# Altium schematic sheet Fonte 24V 15A FAN gnd isolado.SchDoc
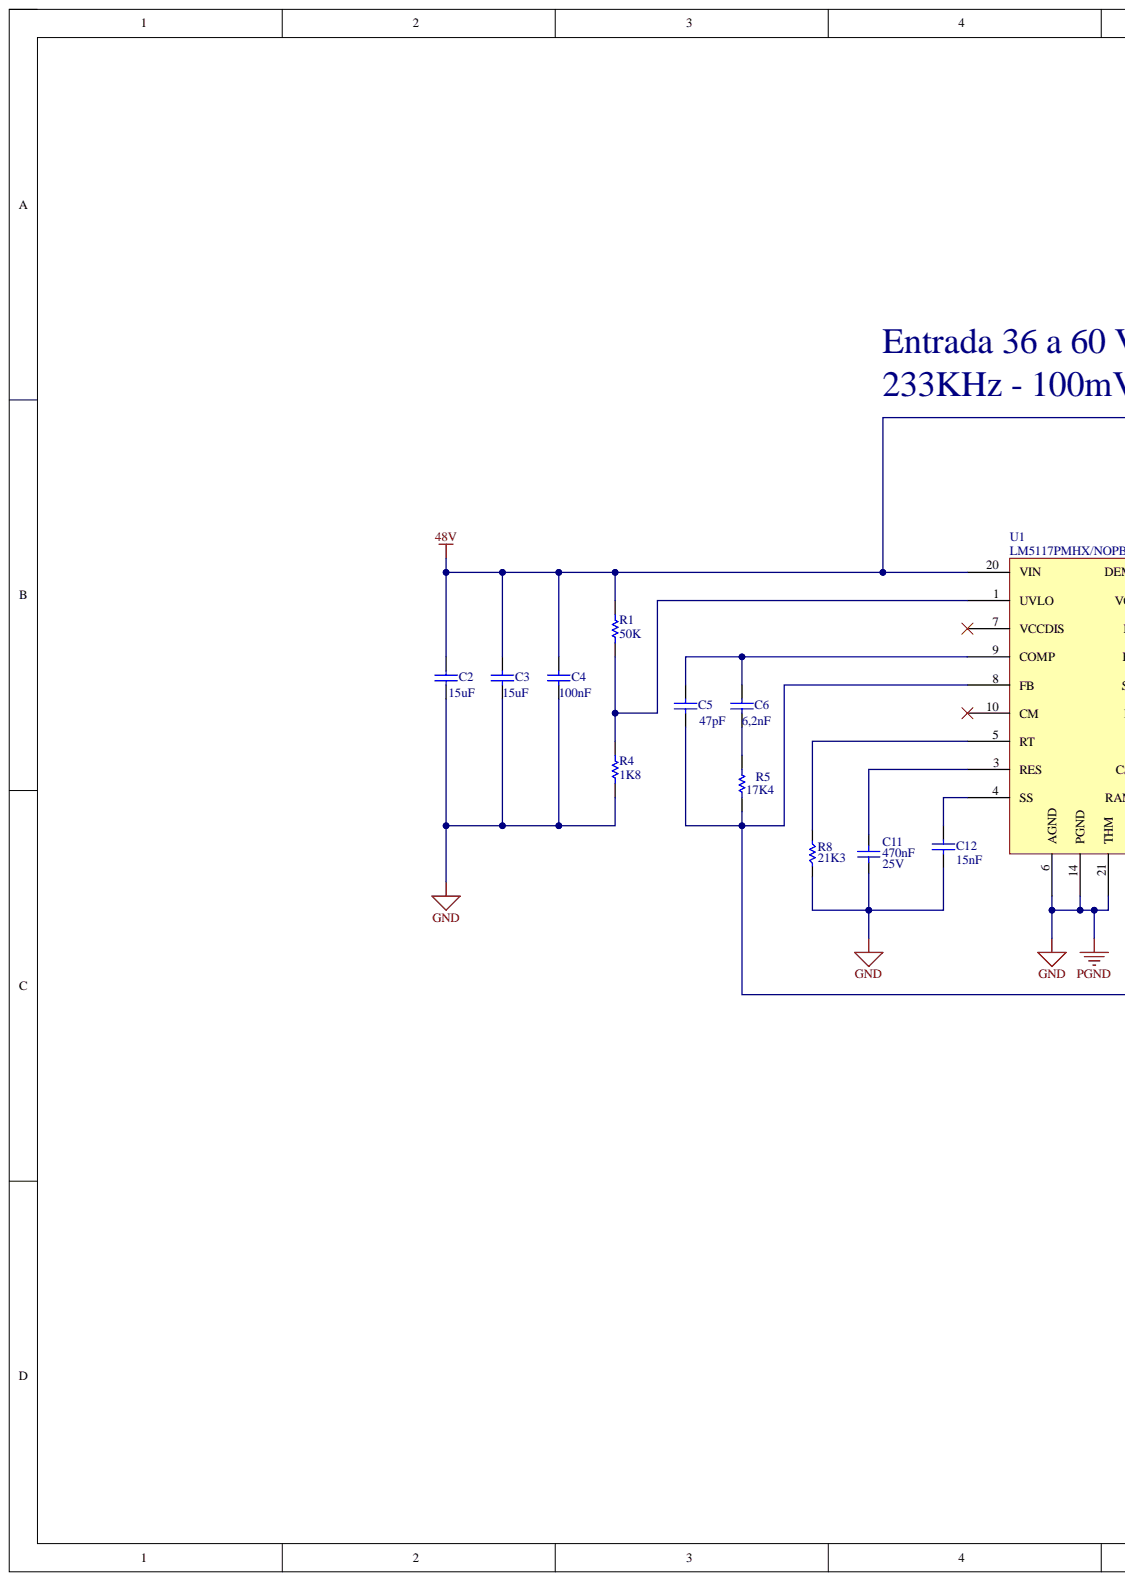
[diagram p0: Fonte 24V 15A FAN gnd isolado.SchDoc, left]
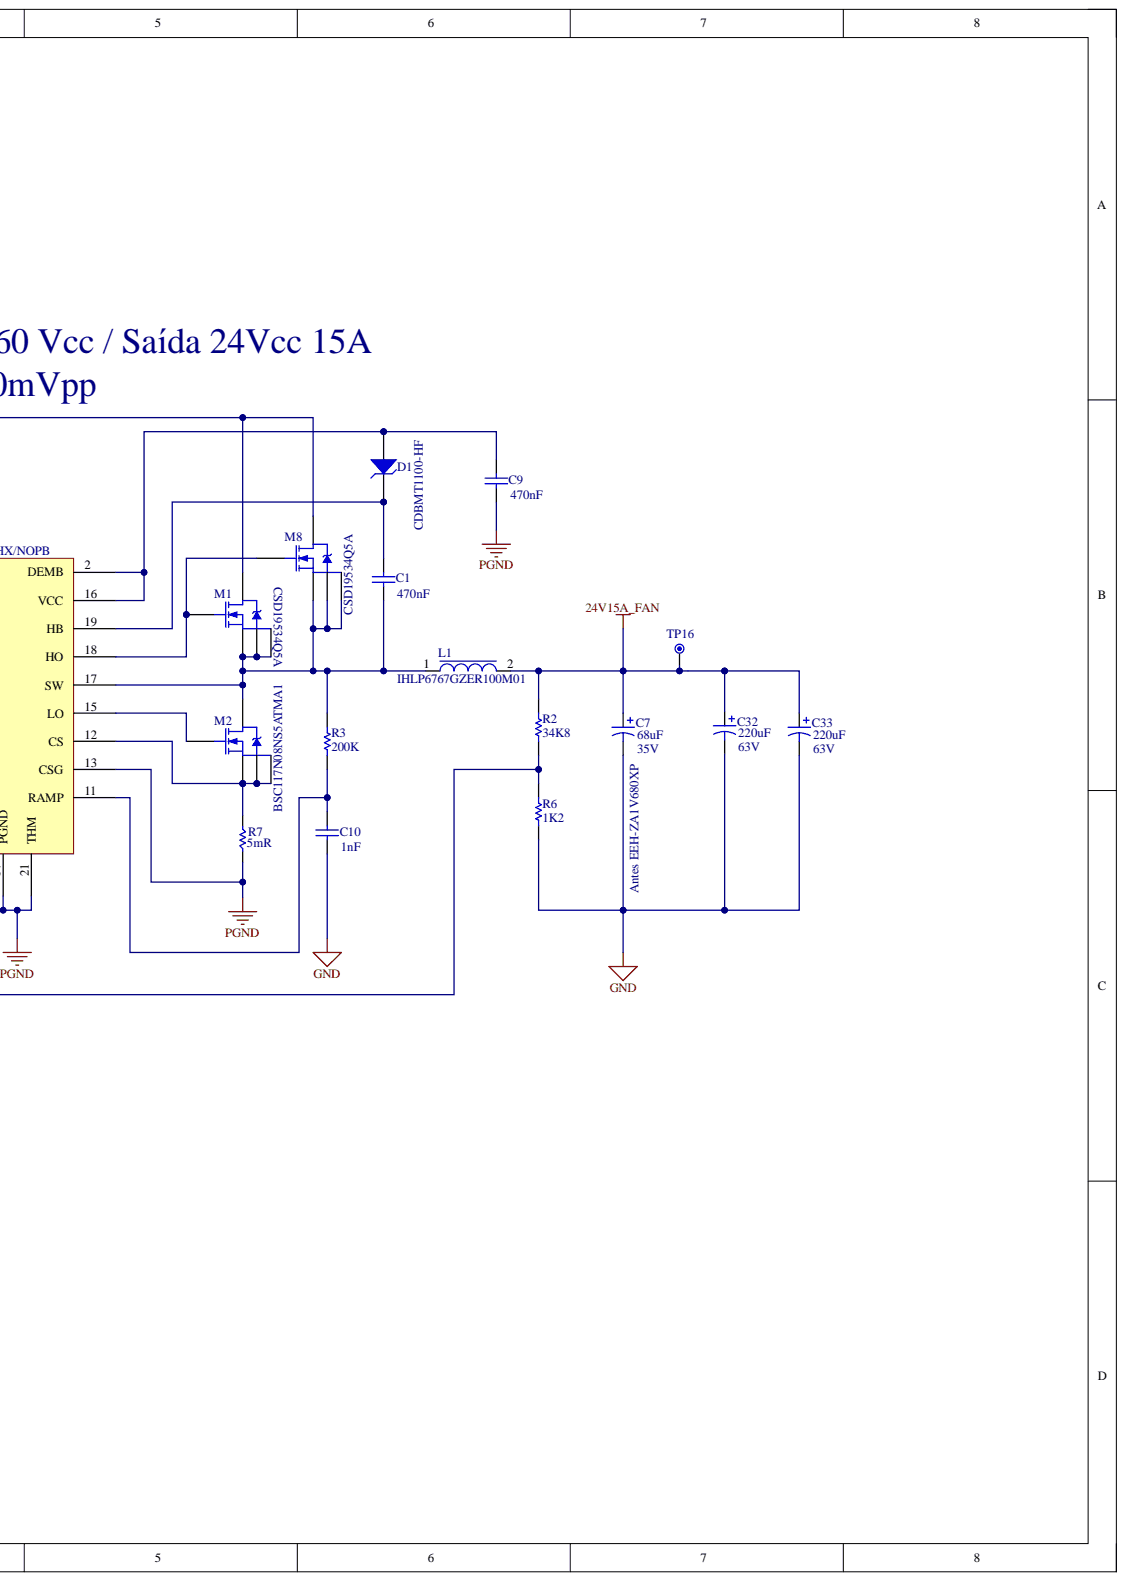
[diagram p1: Fonte 24V 15A FAN gnd isolado.SchDoc, right]

## Parts
C1  GRM188R71A474KA61D: 470nF  [SMD_0603]  (hidden comment: GRM188R71A474KA61D)
C2  C5750X7S2A156M250KB: 15uF  [5750]  (hidden comment: C5750X7S2A156M250KB)
C3  C5750X7S2A156M250KB: 15uF  [5750]  (hidden comment: C5750X7S2A156M250KB)
C4  C1608X7S2A104K080AE: 100nF  [SMD_0603]  (hidden comment: C1608X7S2A104K080AE)
C5  GRM1885C1H470JA01D: 47pF  [SMD_0603]  (hidden comment: GRM1885C1H470JA01D)
C6  C0603C622J5GAC7867: 6,2nF  [SMD_0603]  (hidden comment: C0603C472K1TACAUTO)
C7  870235674004: 68uF  Voltage: 35V  [Cap Aluminio 6,3 com furo]  (hidden comment: 870235674004)
C9  GRM188R71A474KA61D: 470nF  [SMD_0603]  (hidden comment: GRM188R71A474KA61D)
C10  C0603C821J5GACTU: 1nF  [SMD_0603]  (hidden comment: CC0603FRNPO9BN102)
C11  Capacitance: 470nF  Voltage: 25V  [SMD_0603]  (hidden comment: TMF107B7474KAHT)
C12  CGA4F2C0G1H153J085AA: 15nF  [SMD_0603]  (hidden comment: CGA4F2C0G1H153J085AA)
C32  EKYA630ELL221MJ20S: 220uF  Voltage: 63V  [CAP ELE RAD 10 mm]  (hidden comment: EKYA630ELL221MJ20S)
C33  EKYA630ELL221MJ20S: 220uF  Voltage: 63V  [CAP ELE RAD 10 mm]  (hidden comment: EKYA630ELL221MJ20S)
D1  CDBMT1100-HF  [SMD_SOD123-2]
L1  IHLP6767GZER100M01  [IHLP6767GZER6R8M11]
M1  CSD19534Q5A  [TDSON_8]
M2  BSC117N08NS5ATMA1  [TDSON_8]
M8  CSD19534Q5A  [TDSON_8]
R1  RT0603BRD0750KL: 50K  [SMD_0603]  (hidden comment: RT0603BRD0750KL)
R2  CRCW060334K8FKEA: 34K8  [SMD_0603]  (hidden comment: CRCW060334K8FKEA)
R3  RMCF0603FT200K: 200K  [SMD_0603]  (hidden comment: RMCF0603FT200K)
R4  RC0603JR-071K8L: 1K8  [SMD_0603]  (hidden comment: RC0603JR-071K8L)
R5  RC0603FR-0717K4L: 17K4  [SMD_0603]  (hidden comment: RC0603FR-0721K5L)
R6  RC0603FR-071K2L: 1K2  [SMD_0603]  (hidden comment: RC0603FR-071K2L)
R7  Resistance: 5mR  [SMD_1206]  (hidden comment: WSL12065L000FTA)
R8  Resistance: 21K3  [SMD_0603]  (hidden comment: RN73H1JTTD2132B25)
TP16  TP-1MM  [TESTPOINT-1MM]  (value not drawn)  (no BOM)
U1  LM5117PMHX/NOPB  [HTSSOP-20 (PWP0020A)]

## Nets
24V15A_FAN: C7.1 C32.1 C33.1 L1.2 R2.1 TP16.1
48V: C2.2 C3.2 C4.2 M1.5 M8.5 R1.2 U1.20(VIN)
GND: C2.1 C3.1 C4.1 C7.2 C9.1 C10.1 C11.1 C12.2 C32.2 C33.2 R4.1 R6.2 R7.1 R8.1 U1.6(AGND) U1.13(CSG) U1.14(PGND) U1.21(THM)
NetC1_1: C1.1 L1.1 M1.1 M1.2 M1.3 M2.5 M8.1 M8.2 M8.3 R3.2 U1.17(SW)
NetC1_2: C1.2 D1.2 U1.19(HB)
NetC5_1: C5.1 R2.2 R5.1 R6.1 U1.8(FB)
NetC5_2: C5.2 C6.2 U1.9(COMP)
NetC6_1: C6.1 R5.2
NetC9_2: C9.2 D1.1 U1.2(DEMB) U1.16(VCC)
NetC10_2: C10.2 R3.1 U1.11(RAMP)
NetC11_2: C11.2 U1.3(RES)
NetC12_1: C12.1 U1.4(SS)
NetM1_4: M1.4 M8.4 U1.18(HO)
NetM2_1: M2.1 M2.2 M2.3 R7.2 U1.12(CS)
NetM2_4: M2.4 U1.15(LO)
NetR1_1: R1.1 R4.2 U1.1(UVLO)
NetR8_2: R8.2 U1.5(RT)

Not connected: U1 7(VCCDIS) 10(CM)

## Library data of the parts
C1-C6 C9 C10 C12 = Cap Semi: Capacitor (Semiconductor SIM Model); Component Kind: Standard; Library Name: Miscellaneous Devices.IntLib; PackageReference: C1206; Published: 8-Jun-2000; Signal Integrity: Cap Semi; Simulation: CAP; Publisher: Altium Limited
  C1 C5 C6 C9 C10 C12: LatestRevisionDate: 17-Jul-2002; LatestRevisionNote: Re-released for DXP Platform.
  C2-C4: LatestRevisionDate: 29-May-2009; LatestRevisionNote: IPC-7351 Footprint Added.; PackageDescription: Chip Capacitor
C7 C32 C33 = Cap Pol1: Polarized Capacitor (Radial); Component Kind: Standard; LatestRevisionDate: 17-Jul-2002; LatestRevisionNote: Re-released for DXP Platform.; Library Name: Miscellaneous Devices.IntLib; PackageReference: RB7.6-15; Published: 8-Jun-2000; Signal Integrity: Cap Pol; Simulation: CAP; Publisher: Altium Limited
C11 = C-CER-470nF-25V-X7R-0603: Capacitor 470nF 25V 10% X7R 0603 AEC-Q200; Component Kind: Standard; Part Number: TMF107B7474KAHT; Library Name: xmobots_-_comp_library.IntLib
D1 = BAS316-TP: DIODE 100V 500MA SOD323; Component Kind: Standard; Supplier 1: Digi-Key; Supplier Part Number 1: BAS316-TPMSCT-ND; Library Name: XM_Diodes.SchLib
L1 = 74435588200: FIXED IND 10UH 7A 30.4 MOHM SMD; Component Kind: Standard; Supplier 1: Digi-Key; Supplier Part Number 1: 732-4241-1-ND; Library Name: XM_Inductor.SchLib
M1 M2 M8 = BSC117N08NS5ATMA1: MOSFET N-CH 80V 49A Automotive; Component Kind: Standard; Library Name: XM_Fet.SchLib
R1-R6 = Res Semi: Semiconductor Resistor; Component Kind: Standard; LatestRevisionDate: 17-Jul-2002; LatestRevisionNote: Re-released for DXP Platform.; Library Name: Miscellaneous Devices.IntLib; PackageReference: AXIAL-0.5; Published: 8-Jun-2000; Signal Integrity: Res Semi; Simulation: RES; Publisher: Altium Limited
R7 = R-5mR-1/4W-1%-1206: Resistor 5m ohms 1/4W 1% 1206; Component Kind: Standard; Library Name: WarLib.IntLib; Part Number: WSL12065L000FTA; Power: 1/4W; Tolerance: ±1%
R8 = R-21.3K-1/10W-1%-0603: Resistor 21.3k ohms 1/10W 1% 0603; Component Kind: Standard; Library Name: WarLib.IntLib; Part Number: RN73H1JTTD2132B25; Power: 1/10W; Tolerance: ±1%
U1 = LM5117PMHX/NOPB: Component Kind: Standard; Library Name: XM_IC.SchLib
TP16 = TP-1MM: Library Name: xmobots_-_comp_library.IntLib
